# Altium schematic sheet uP_Riser.SchDoc(uP_Riser)
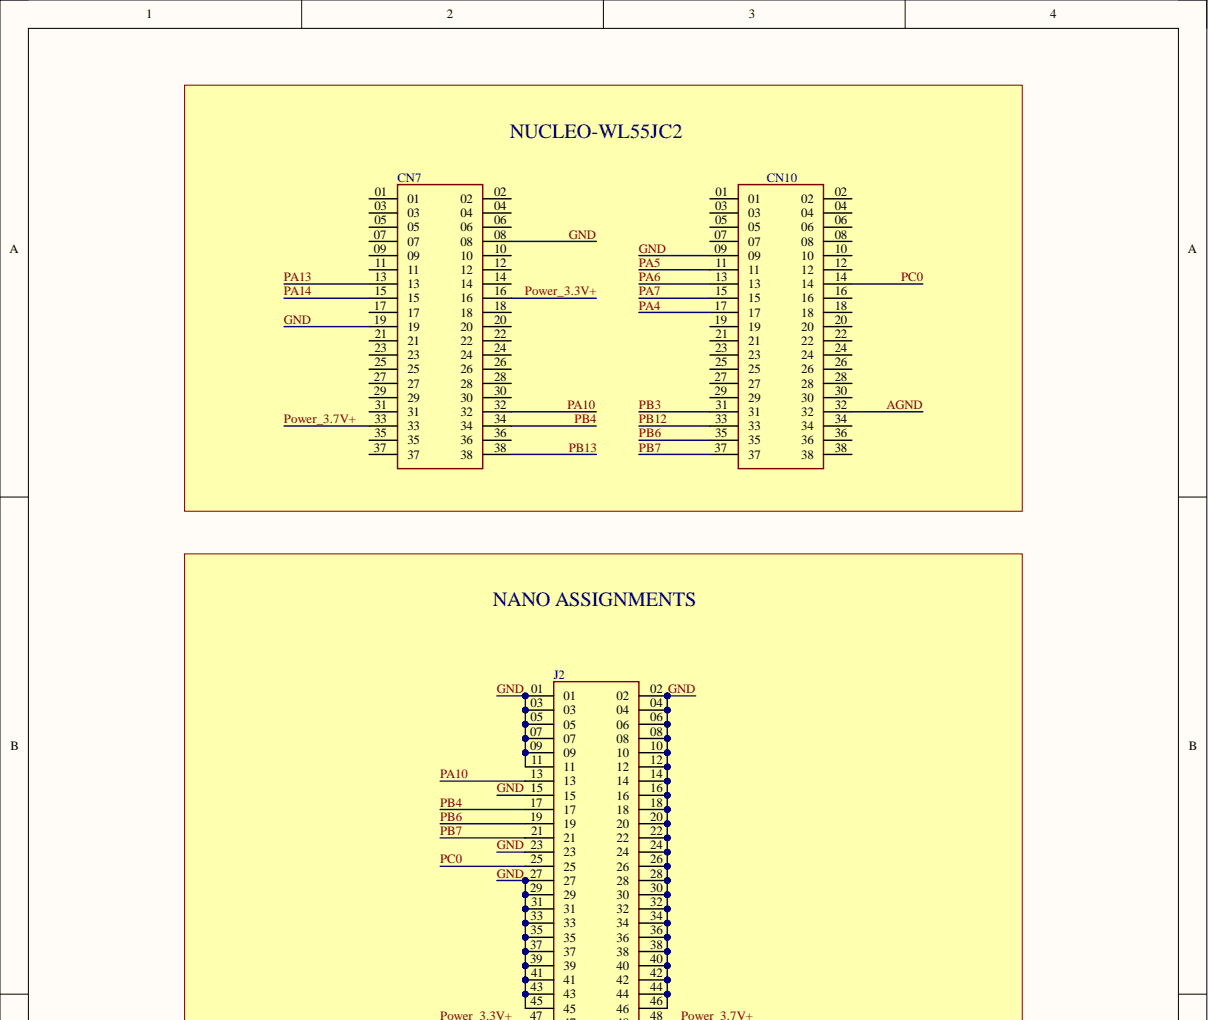
[diagram p0: uP_Riser.SchDoc, top]
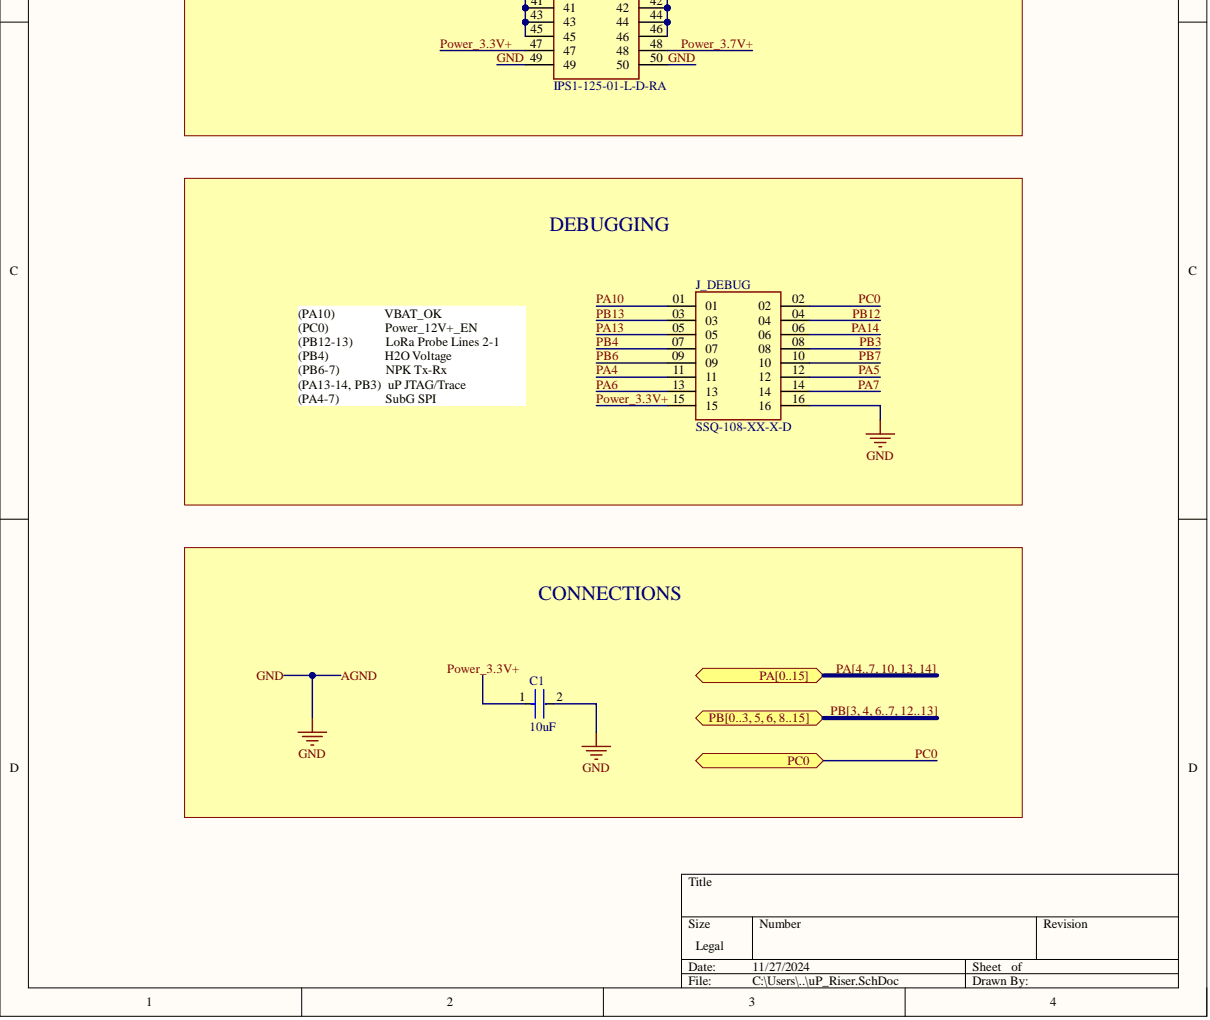
[diagram p1: uP_Riser.SchDoc, bottom]

## Parts
C1  Capacitance: 10uF  [FP-GRM188-0_1-e0_2_0_55-IPC_A]  (hidden comment: GRM188R60J106ME47D)
CN7  SSW-119-01-X-D  [SAMTEC_SSW-119-01-X-D]  (value not drawn)
CN10  SSW-119-01-X-D  [SAMTEC_SSW-119-01-X-D]  (value not drawn)
J2  IPS1-125-01-L-D-RA  [SAMTEC_IPS1-125-01-L-D-RA]
J_DEBUG  SSQ-108-XX-X-D  [SAMTEC_SSQ-108-XX-X-D]

## Ports
PA0, PA[0..15], PB[0..3, 5, 6, 8..15], PC0

## Nets
AGND: C1.2 CN7.08 CN7.19 CN10.09 CN10.32 J2.01 J2.02 J2.03 J2.04 J2.05 J2.06 J2.07 J2.08 J2.09 J2.10 J2.11 J2.12 J2.14 J2.15 J2.16 J2.18 J2.20 J2.22 J2.23 J2.24 J2.26 J2.27 J2.28 J2.29 J2.30 J2.31 J2.32 J2.33 J2.34 J2.35 J2.36 J2.37 J2.38 J2.39 J2.40 J2.41 J2.42 J2.43 J2.44 J2.45 J2.46 J2.49 J2.50 J_DEBUG.16
PA4: CN10.17 J_DEBUG.11 ; port PA[0..15]
PA5: CN10.11 J_DEBUG.12
PA6: CN10.13 J_DEBUG.13
PA7: CN10.15 J_DEBUG.14
PA10: CN7.32 J2.13 J_DEBUG.01
PA13: CN7.13 J_DEBUG.05
PA14: CN7.15 J_DEBUG.06
PB3: CN10.31 J_DEBUG.08
PB4: CN7.34 J2.17 J_DEBUG.07
PB6: CN10.35 J2.19 J_DEBUG.09
PB7: CN10.37 J2.21 J_DEBUG.10
PB12: CN10.33 J_DEBUG.04
PB13: CN7.38 J_DEBUG.03
PC0: CN10.14 J2.25 J_DEBUG.02 ; port PC0
Power_3.3V+: C1.1 CN7.16 J2.47 J_DEBUG.15
Power_3.7V+: CN7.33 J2.48
PA[4..7, 10, 13, 14]: ; port PA[0..15]  [also labelled PA]
PB[3, 4, 6..7, 12..13]: ; port PB[0..3, 5, 6, 8..15]  [also labelled PB]

Not connected: CN7 01 02 03 04 05 06 07 09 10 11 12 14 17 18 20 21 22 23 24 25 26 27 28 29 30 31 35 36 37; CN10 01 02 03 04 05 06 07 08 10 12 16 18 19 20 21 22 23 24 25 26 27 28 29 30 34 36 38

## Library data of the parts
C1 = CMP-2006-02792-2: Chip Multilayer Ceramic Capacitors for General Purpose, 0603, 10uF, X5R, 15%, 20%, 6.3V; Case Code (Imperial): 0603; Case Code (Metric): 1608; Case/Package: 0603; Component Kind: Standard; Depth: 0.8mm; Dielectric: X5R; Height: 0.8mm; Lead Free: Lead Free; Length: 1.6mm; Library Name: Altium Content Vault; Material: Ceramic; Max Operating Temperature: 85°C; Min Operating Temperature: -55°C; Mount: Surface Mount; Package Quantity: 4000; Packaging: Tape and Reel; Radiation Hardening: No; REACH SVHC: No SVHC; Termination: SMD/SMT; Thickness: 0.035inch; Tolerance: 20%; Voltage: 6.3V; Voltage Rating: 6.3V; Voltage Rating (DC): 6.3V; Width: 0.031inch
CN7 CN10 = SSW-119-01-X-D: SSW Series .100" Tiger Buyâ„¢ Socket Strip; MF: Samtec; Availability: Unavailable; Component Kind: Standard; Library Name: SSW-119-01-T-D.IntLib; MP: SSW-119-01-X-D; Package: None; Price: None
J2 = IPS1-125-01-L-D-RA: 50 Position Receptacle Connector 0.100 (2.54mm) Through Hole, Right Angle Gold; MF: Samtec; Check_prices: https://www.snapeda.com/parts/IPS1-125-01-L-D-RA/Samtec/view-part/3459101/?ref=eda; Component Kind: Standard; Digi-Key_Part_Number: IPS1-125-01-L-D-RA-PL-ND; Library Name: IPS1-125-01-L-D-RA.IntLib; MP: IPS1-125-01-L-D-RA; Package: None; Purchase-URL: https://pricing.snapeda.com/search/part/IPS1-125-01-L-D-RA/?ref=eda; SnapEDA_Link: https://www.snapeda.com/parts/IPS1-125-01-L-D-RA/Samtec/view-part/3459101/?ref=snap
J_DEBUG = SSQ-108-XX-X-D: SSQ - Samtec 2.54mm Square Tail Dual Row Socket Strip 8.5mm Profile - Fast Stock; Availability: Unavailable; Component Kind: Standard; Library Name: SSQ-108-XX-X-D.IntLib; MF: Samtec; MP: SSQ-108-XX-X-D; Package: None; Price: None
